# Altium schematic sheet GasCap.CMWX1ZZABZ-078.SchDoc
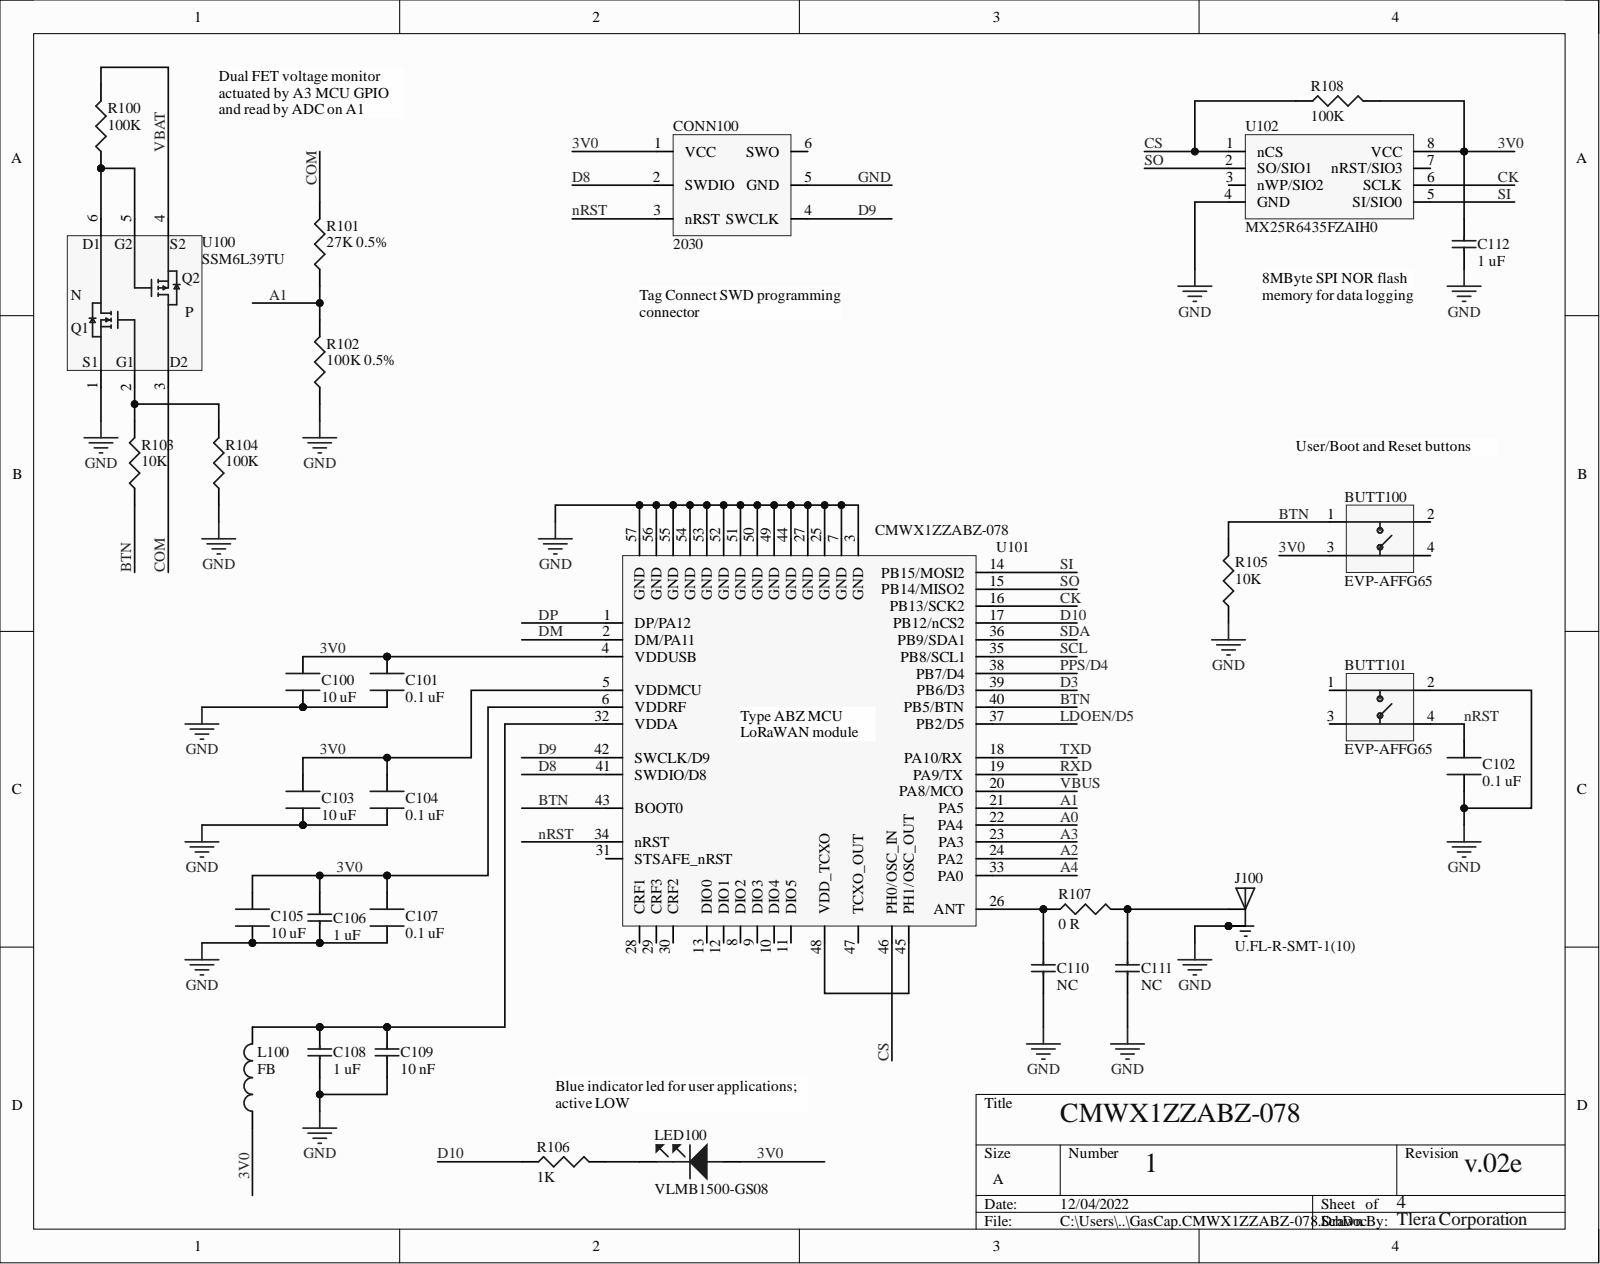
[diagram p0: GasCap.CMWX1ZZABZ-078.SchDoc]

## Parts
BUTT100  EVP-AFFG65  [EVP-AFFG65]
BUTT101  EVP-AFFG65  [EVP-AFFG65]
C100  10 uF  [402Cap]
C101  0.1 uF  [402Cap]
C102  0.1 uF  [402Cap]
C103  10 uF  [402Cap]
C104  0.1 uF  [402Cap]
C105  10 uF  [402Cap]
C106  1 uF  [402Cap]
C107  0.1 uF  [402Cap]
C108  1 uF  [402Cap]
C109  10 nF  [402Cap]
C110  NC  [402Cap]
C111  NC  [402Cap]
C112  1 uF  [402Cap]
CONN100  2030  [Tag_Connect_2030]
J100  U.FL-R-SMT-1(10)  [HRS_U.FL-R-SMT-1(10)]
L100  FB  [402IND]
LED100  VLMB1500-GS08  [0402LED]
R100  100K  [402RES]
R101  27K 0.5%  [402RES]
R102  100K 0.5%  [402RES]
R103  10K  [402RES]
R104  100K  [402RES]
R105  10K  [402RES]
R106  1K  [402RES]
R107  0 R  [402RES]
R108  100K  [402RES]
U100  SSM6L39TU  [SSM6L40TU]
U101  CMWX1ZZABZ-078  [XCVR_CMWX1ZZABZ-078]
U102  MX25R6435FZAIH0  [MX25R6435FZAIH0]

## Nets
3V0: BUTT100.3 C100.2 C101.2 C103.2 C104.2 C105.2 C106.2 C107.2 C112.2 CONN100.1(VCC) L100.1 LED100.2 R108.2 U101.4(VDDUSB) U101.5(VDDMCU) U101.6(VDDRF) U102.8(VCC)
A0: U101.22(PA4)
A1: R101.1 R102.2 U101.21(PA5)
A2: U101.24(PA2)
A3: U101.23(PA3)
A4: U101.33(PA0)
BTN: BUTT100.1 R103.1 R105.2 U101.40(PB5/BTN) U101.43(BOOT0)
CK: U101.16(PB13/SCK2) U102.6(SCLK)
COM: R101.2 U100.3
CS: R108.1 U101.46(PH0/OSC_IN) U102.1(nCS)
D3: U101.39(PB6/D3)
D8: CONN100.2(SWDIO) U101.41(SWDIO/D8)
D9: CONN100.4(SWCLK) U101.42(SWCLK/D9)
D10: R106.1 U101.17(PB12/nCS2)
DM: U101.2(DM/PA11)
DP: U101.1(DP/PA12)
GND: BUTT101.2 C100.1 C101.1 C102.1 C103.1 C104.1 C105.1 C106.1 C107.1 C108.1 C109.1 C110.1 C111.1 C112.1 CONN100.5(GND) J100.1 J100.3 R102.1 R104.1 R105.1 U100.1 U101.3(GND) U101.7(GND) U101.25(GND) U101.27(GND) U101.44(GND) U101.49(GND) U101.50(GND) U101.51(GND) U101.52(GND) U101.53(GND) U101.54(GND) U101.55(GND) U101.56(GND) U101.57(GND) U102.4(GND)
LDOEN/D5: U101.37(PB2/D5)
nRST: BUTT101.4 C102.2 CONN100.3(nRST) U101.34(nRST)
PPS/D4: U101.38(PB7/D4)
RXD: U101.19(PA9/TX)
SCL: U101.35(PB8/SCL1)
SDA: U101.36(PB9/SDA1)
SI: U101.14(PB15/MOSI2) U102.5(SI/SIO0)
SO: U101.15(PB14/MISO2) U102.2(SO/SIO1)
TXD: U101.18(PA10/RX)
VBAT: R100.2 U100.4
VBUS: U101.20(PA8/MCO)
NetC108_2: C108.2 C109.2 L100.2 U101.32(VDDA)
NetC110_2: C110.2 R107.1 U101.26(ANT)
NetC111_2: C111.2 J100.2 R107.2
NetLED100_1: LED100.1 R106.2
NetR100_1: R100.1 U100.5 U100.6(D1)
NetR103_2: R103.2 R104.2 U100.2
NetU101_45: U101.45(PH1/OSC_OUT) U101.48(VDD_TCXO)

Not connected: BUTT100 2 4; BUTT101 1 3; CONN100 6(SWO); U101 8(DIO2) 9(DIO3) 10(DIO4) 11(DIO5) 12(DIO1) 13(DIO0) 28(CRF1) 29(CRF3) 30(CRF2) 31(STSAFE_nRST) 47(TCXO_OUT); U102 3(nWP/SIO2) 7(nRST/SIO3)

## Library data of the parts
BUTT100 BUTT101 = EVP-AFFG65: Tactile Switches SPST-NO Force 160 gf OFF-(ON) .7mm H; Manufacturer: Panasonic; Category: Tactile Switches; Component Kind: Standard; ComponentLink1Description: https://datasheet.ciiva.com/16439/atk0000ce31-16439765.pdf?src-supplier=Future+Electronics; ComponentLink1URL: https://datasheet.ciiva.com/16439/atk0000ce31-16439765.pdf?src-supplier=Future+Electronics; ComponentLink2Description: https://datasheet.ciiva.com/7987/1679591-7987594.pdf?src-supplier=Element14; ComponentLink2URL: https://datasheet.ciiva.com/7987/1679591-7987594.pdf?src-supplier=Element14; Manufacturer Part Number: EVP-AFFG65; Pricing 1: 1=0.91000, 10=0.87400, 25=0.81720, 100=0.70940, 250=0.64556, 500=0.59846, 1000=0.55476, 2500=0.50433 (USD); RoHS: Yes; Stock 1: 7880; Supplier 1: Digi-Key; Supplier Part Number 1: P15511CT-ND; Library Name: Gnat.SchLib
C100 C103 C105 = CL05A106MQ5NUNC: 0402 10uF Ceramic Capacitor, Multilayer, Ceramic, 6.3V, 20% +Tol, 20% -Tol, X5R, 15% TC, 10uF, Surface Mount, 0402; Manufacturer: Samsung; Multilayer: Yes; Capacitance: 10uF; Capacitor Type: Ceramic; Category: Ceramic Capacitors; Component Kind: Standard; ComponentLink1Description: https://datasheet.ciiva.com:443/pdfs/VipMasterIC/IC/SEMS/SEMS-S-A0008521219/SEMS-S-A0008521300-1.pdf?src-supplier=IHS+Markit; ComponentLink1URL: https://datasheet.ciiva.com:443/pdfs/VipMasterIC/IC/SEMS/SEMS-S-A0008521219/SEMS-S-A0008521300-1.pdf?src-supplier=IHS+Markit; ComponentLink2Description: https://datasheet.ciiva.com/16437/cl05a106mq5nunc-16437356.pdf?src-supplier=Future+Electronics; ComponentLink2URL: https://datasheet.ciiva.com/16437/cl05a106mq5nunc-16437356.pdf?src-supplier=Future+Electronics; ComponentLink3Description: https://datasheet.ciiva.com/26877/getdatasheetpartid-355289-26877841.pdf?src-supplier=Verical; ComponentLink3URL: https://datasheet.ciiva.com/26877/getdatasheetpartid-355289-26877841.pdf?src-supplier=Verical; Dielectric Material: Ceramic; Height: 0.7mm; JESD-609 Code: e3; Length: 1mm; Manufacturer Part Number: CL05A106MQ5NUNC; Max Operating Temperature: 85°C; Min Operating Temperature: -55°C; Mount: Surface Mount; Negative Tolerance: 20%; Number of Pins: 2; Package Shape: Rectangular; Package Style: SMTMeter; Packaging: Tape and Reel; Positive Tolerance: 20%; Pricing 1: 1=0.26000, 10=0.17600, 50=0.10540, 100=0.08900, 500=0.06086, 1000=0.05384, 2500=0.05150, 5000=0.04682 (USD); Rated DC Voltage (URdc): 6.3V; REACH SVHC: Yes; RoHS: Yes; RoHS Compliant: Yes; Size Code: 0402; Stock 1: 9272723; Supplier 1: Digi-Key; Supplier Part Number 1: 1276-1451-1-ND; Temperature Characteristics Code: X5R; Terminal Finish: Nickel; Termination: Wraparound; Width: 0.5mm; Library Name: Gnat.SchLib
C101 C102 C104 C107 = CL05B104KO5NNNC: 0402 0.1 uF Ceramic Capacitor, Multilayer, Ceramic, 16V, 10% +Tol, 10% -Tol, X7R, 15% TC, 0.1uF, Surface Mount, 0402; Manufacturer: Samsung; Capacitance: 100nF; Multilayer: Yes; Capacitor Type: Ceramic; Category: Ceramic Capacitors; Component Kind: Standard; ComponentLink1Description: https://datasheet.ciiva.com:443/pdfs/VipMasterIC/IC/SEMS/SEMS-S-A0008384515/SEMS-S-A0008872921-1.pdf?src-supplier=IHS+Markit; ComponentLink1URL: https://datasheet.ciiva.com:443/pdfs/VipMasterIC/IC/SEMS/SEMS-S-A0008384515/SEMS-S-A0008872921-1.pdf?src-supplier=IHS+Markit; ComponentLink2Description: https://datasheet.ciiva.com/26920/getdatasheetpartid-359683-26920169.pdf?src-supplier=Verical; ComponentLink2URL: https://datasheet.ciiva.com/26920/getdatasheetpartid-359683-26920169.pdf?src-supplier=Verical; ComponentLink3Description: https://datasheet.ciiva.com/29198/458718-da-01-en-vs-kondensator-0402-29198423.pdf?src-supplier=Conrad; ComponentLink3URL: https://datasheet.ciiva.com/29198/458718-da-01-en-vs-kondensator-0402-29198423.pdf?src-supplier=Conrad; ComponentLink4Description: https://datasheet.ciiva.com/16208/cl05b104kq5nnnc-16208343.pdf?src-supplier=Future+Electronics; ComponentLink4URL: https://datasheet.ciiva.com/16208/cl05b104kq5nnnc-16208343.pdf?src-supplier=Future+Electronics; ComponentLink5Description: https://datasheet.ciiva.com/26309/mlcc-catalogdownload2013-eng-26309003.pdf?src-supplier=Distrelec; ComponentLink5URL: https://datasheet.ciiva.com/26309/mlcc-catalogdownload2013-eng-26309003.pdf?src-supplier=Distrelec; Dielectric Material: Ceramic; Height: 0.55mm; JESD-609 Code: e3; Length: 1mm; Manufacturer Part Number: CL05B104KO5NNNC; Max Operating Temperature: 125°C; Min Operating Temperature: -55°C; Mount: Surface Mount; Negative Tolerance: 10%; Number of Pins: 2; Package Shape: Rectangular; Package Style: SMTMeter; Packaging: Tape and Reel; Positive Tolerance: 10%; Pricing 1: 1=0.10000, 10=0.02600, 50=0.01400, 100=0.01150, 500=0.00820, 1000=0.00644, 2500=0.00586, 5000=0.00539 (USD); Rated DC Voltage (URdc): 16V; REACH SVHC: Yes; RoHS: Yes; RoHS Compliant: Yes; Size Code: 0402; Stock 1: 30141598; Supplier 1: Digi-Key; Supplier Part Number 1: 1276-1001-1-ND; Temperature Characteristics Code: X7R; Terminal Finish: Nickel; Termination: Wraparound; Width: 0.5mm; Library Name: Gnat.SchLib
C106 C108 C112 = GRT155R61C105KE01D: 0402 1 uF Ceramic Capacitor, Multilayer, Ceramic, 16V, 10% +Tol, 10% -Tol, X5R, 15% TC, 1uF, Surface Mount, 0402; Manufacturer: Murata; Multilayer: Yes; Reference Standard: AEC-Q200; Capacitance: 1uF; Capacitor Type: Ceramic; Category: Ceramic Capacitors; Component Kind: Standard; ComponentLink1Description: https://datasheet.ciiva.com:443/pdfs/VipMasterIC/IC/MURE/MURE-S-A0004410517/MURE-S-A0004410643-1.pdf?src-supplier=IHS+Markit; ComponentLink1URL: https://datasheet.ciiva.com:443/pdfs/VipMasterIC/IC/MURE/MURE-S-A0004410517/MURE-S-A0004410643-1.pdf?src-supplier=IHS+Markit; ComponentLink2Description: http://search.murata.co.jp/Ceramy/image/img/A01X/G101/ENG/GRT155R61C105KE01-01.pdf?src-supplier=Digi-Key; ComponentLink2URL: http://search.murata.co.jp/Ceramy/image/img/A01X/G101/ENG/GRT155R61C105KE01-01.pdf?src-supplier=Digi-Key; Dielectric Material: Ceramic; JESD-609 Code: e3; Manufacturer Part Number: GRT155R61C105KE01D; Max Operating Temperature: 85°C; Min Operating Temperature: -55°C; Mount: Surface Mount; Negative Tolerance: 10%; Number of Pins: 2; Package Shape: Rectangular; Packaging: Tape and Reel; Positive Tolerance: 10%; Pricing 1: 1=0.12000, 10=0.08300, 50=0.04740, 100=0.03920, 500=0.02752, 1000=0.02278, 2500=0.02120, 5000=0.01939 (USD); Rated DC Voltage (URdc): 16V; REACH SVHC: Yes; RoHS: Yes; RoHS Compliant: Yes; Size Code: 0402; Stock 1: 691618; Supplier 1: Digi-Key; Supplier Part Number 1: 490-12255-1-ND; Temperature Characteristics Code: X5R; Terminal Finish: Nickel; Termination: Wraparound
  C106 C108: Library Name: Gnat.SchLib
  C112: Library Name: GasCap.SchLib
C109 = 04023C103KAT2A: 0402 10 nF Ceramic Capacitor, Multilayer, Ceramic, 25V, 10% +Tol, 10% -Tol, X7R, 15% TC, 0.01uF, Surface Mount, 0402; Manufacturer: Kyocera AVX; Capacitance: 10nF; Multilayer: Yes; Capacitor Type: Ceramic; Category: Ceramic Capacitors; Component Kind: Standard; ComponentLink1Description: https://datasheet.ciiva.com:443/pdfs/VipMasterIC/IC/AVXC/AVXC-S-A0009640452/AVXC-S-A0009640452-1.pdf?src-supplier=IHS+Markit; ComponentLink1URL: https://datasheet.ciiva.com:443/pdfs/VipMasterIC/IC/AVXC/AVXC-S-A0009640452/AVXC-S-A0009640452-1.pdf?src-supplier=IHS+Markit; ComponentLink2Description: https://datasheet.ciiva.com/11788/0900766b80dc2409-11788540.pdf?src-supplier=RSComponents; ComponentLink2URL: https://datasheet.ciiva.com/11788/0900766b80dc2409-11788540.pdf?src-supplier=RSComponents; ComponentLink3Description: https://datasheet.ciiva.com/1123/cx7r-1123312.pdf?src-supplier=Element14; ComponentLink3URL: https://datasheet.ciiva.com/1123/cx7r-1123312.pdf?src-supplier=Element14; ComponentLink4Description: https://datasheet.ciiva.com/14590/70001075-14590631.pdf?src-supplier=Allied+Electronics; ComponentLink4URL: https://datasheet.ciiva.com/14590/70001075-14590631.pdf?src-supplier=Allied+Electronics; ComponentLink5Description: https://datasheet.ciiva.com/14596/70001075-14596734.pdf?src-supplier=Allied+Electronics; ComponentLink5URL: https://datasheet.ciiva.com/14596/70001075-14596734.pdf?src-supplier=Allied+Electronics; ComponentLink6Description: https://datasheet.ciiva.com/1123/1679506-1123313.pdf?src-supplier=Element14; ComponentLink6URL: https://datasheet.ciiva.com/1123/1679506-1123313.pdf?src-supplier=Element14; ComponentLink7Description: https://datasheet.ciiva.com/7709/1679506-7709526.pdf?src-supplier=Element14; ComponentLink7URL: https://datasheet.ciiva.com/7709/1679506-7709526.pdf?src-supplier=Element14; ComponentLink8Description: https://datasheet.ciiva.com/151/cx7r-151765.pdf?src-supplier=Digi-Key; ComponentLink8URL: https://datasheet.ciiva.com/151/cx7r-151765.pdf?src-supplier=Digi-Key; ComponentLink9Description: https://datasheet.ciiva.com/151/cx7r-151766.pdf?src-supplier=Digi-Key; ComponentLink9URL: https://datasheet.ciiva.com/151/cx7r-151766.pdf?src-supplier=Digi-Key; ComponentLink10Description: https://datasheet.ciiva.com/151/cx7r-151767.pdf?src-supplier=Digi-Key; ComponentLink10URL: https://datasheet.ciiva.com/151/cx7r-151767.pdf?src-supplier=Digi-Key; Dielectric Material: Ceramic; Height: 0.56mm; JESD-609 Code: e3; Length: 1mm; Manufacturer Part Number: 04023C103KAT2A; Max Operating Temperature: 125°C; Min Operating Temperature: -55°C; Mount: Surface Mount; Negative Tolerance: 10%; Number of Pins: 2; Package Shape: Rectangular; Package Style: SMTMeter; Packaging: Tape and Reel; Positive Tolerance: 10%; Pricing 1: 1=0.10000, 10=0.01600, 50=0.00860, 100=0.00700, 500=0.00500, 1000=0.00393, 2500=0.00358, 5000=0.00329 (USD); Rated DC Voltage (URdc): 25V; REACH SVHC: Yes; RoHS: Yes; RoHS Compliant: Yes; Size Code: 0402; Stock 1: 3781761; Supplier 1: Digi-Key; Supplier Part Number 1: 478-1113-1-ND; Temperature Characteristics Code: X7R; Terminal Finish: Nickel; Termination: Wraparound; Width: 0.5mm; Library Name: Gnat.SchLib
C110 C111 = C0402-N.C.: Not mounted; Artikkelnr Noca: N.A.; Assembly: Not mounted; Note: Chip Cap; Pad Count: 2; Value: N.C.; Component Class: Capacitors; Component Kind: Standard; ComponentLink1Description: Datasheet; ComponentLink1URL: N.A.; ComponentLink2Description: Supplier link; ComponentLink2URL: N.A.; Footprint Doc: 0402; HelpURL: N.A.; LatestRevisionDate: 2009-05-08; Manufacturer: N.A.; Manufacturer Part Number: N.A.; Part Number: C0402-N.C.; Part number IPS: N.A.; Part number Norautron: N.A.; PartId: 100200105; Published: 2009-05-08; Supplier 1: N.A.; Supplier 2: N.A.; Supplier Part Number 1: N.A.; Supplier Part Number 2: N.A.; Author: rsk; Library Name: GasCap.SchLib
CONN100 = Tag_Connect_2030: Tag Connect 2030; Component Kind: Standard; Library Name: GasCap.SchLib
J100 = U.FL-R-SMT-1(10): U.FL Series 6 Ghz 50 Ohm Ultra-small SMT Coaxial Cable Receptacle; Availability: Unavailable; Component Kind: Standard; MP: U.FL-R-SMT-1(10); Package: None; Price: None; Library Name: Gnat.SchLib
L100 = BLM15HD102SN1D: 0402 Ferrite Chip, 1 Function(s), 0.25A, 2 Pin(s); Manufacturer: Murata; Manufacturer Part Number: BLM15AX121SN1D; Current Rating: 700mA; DC Resistance-Max: 130mR; Max Frequency: 100MHz; Number of Functions: 1; Output Impedance: 120R; Case Code: 0402; Category: Inductors; Component Kind: Standard; ComponentLink1Description: https://datasheet.ciiva.com:443/pdfs/VipMasterIC/IC/MURE/MURE-S-A0010009827/MURE-S-A0010009827-1.pdf?src-supplier=IHS+Markit; ComponentLink1URL: https://datasheet.ciiva.com:443/pdfs/VipMasterIC/IC/MURE/MURE-S-A0010009827/MURE-S-A0010009827-1.pdf?src-supplier=IHS+Markit; ComponentLink2Description: https://datasheet.ciiva.com/41449/001172167-da-01-en-drossel-0402-120r-1-0x0-5mm-10000st-41449456.pdf?src-supplier=Conrad; ComponentLink2URL: https://datasheet.ciiva.com/41449/001172167-da-01-en-drossel-0402-120r-1-0x0-5mm-10000st-41449456.pdf?src-supplier=Conrad; ComponentLink3Description: https://datasheet.ciiva.com/1012/l0110e-1012387.pdf?src-supplier=Digi-Key; ComponentLink3URL: https://datasheet.ciiva.com/1012/l0110e-1012387.pdf?src-supplier=Digi-Key; ComponentLink4Description: https://datasheet.ciiva.com/1012/l0110e-1012386.pdf?src-supplier=Digi-Key; ComponentLink4URL: https://datasheet.ciiva.com/1012/l0110e-1012386.pdf?src-supplier=Digi-Key; ComponentLink5Description: https://datasheet.ciiva.com/1012/l0110e-1012388.pdf?src-supplier=Digi-Key; ComponentLink5URL: https://datasheet.ciiva.com/1012/l0110e-1012388.pdf?src-supplier=Digi-Key; ComponentLink6Description: https://datasheet.ciiva.com:443/pdfs/VipMasterIC/IC/MURE/MURE-S-A0008871331/MURE-S-A0008871390-1.pdf?src-supplier=IHS+Markit; ComponentLink6URL: https://datasheet.ciiva.com:443/pdfs/VipMasterIC/IC/MURE/MURE-S-A0008871331/MURE-S-A0008871390-1.pdf?src-supplier=IHS+Markit; ComponentLink7Description: https://datasheet.ciiva.com/27054/1844707-27054220.pdf?src-supplier=Jameco; ComponentLink7URL: https://datasheet.ciiva.com/27054/1844707-27054220.pdf?src-supplier=Jameco; ComponentLink8Description: https://datasheet.ciiva.com/11799/0900766b811b5866-11799124.pdf?src-supplier=RSComponents; ComponentLink8URL: https://datasheet.ciiva.com/11799/0900766b811b5866-11799124.pdf?src-supplier=RSComponents; ComponentLink9Description: https://datasheet.ciiva.com/18089/getdatasheetpartid-153027-18089625.pdf?src-supplier=Verical; ComponentLink9URL: https://datasheet.ciiva.com/18089/getdatasheetpartid-153027-18089625.pdf?src-supplier=Verical; ComponentLink10Description: https://datasheet.ciiva.com/41425/001171683-da-01-en-chip-drossel0402-1000r-250ma-1-0x0-5mm-41425767.pdf?src-supplier=Conrad; ComponentLink10URL: https://datasheet.ciiva.com/41425/001171683-da-01-en-chip-drossel0402-1000r-250ma-1-0x0-5mm-41425767.pdf?src-supplier=Conrad; ComponentLink11Description: https://datasheet.ciiva.com/41449/001172200-da-01-en-drossel-0402-1000r-21-0x0-5mm-10000st-41449488.pdf?src-supplier=Conrad; ComponentLink11URL: https://datasheet.ciiva.com/41449/001172200-da-01-en-drossel-0402-1000r-21-0x0-5mm-10000st-41449488.pdf?src-supplier=Conrad; ComponentLink12Description: https://datasheet.ciiva.com/1466/5168-1466860.pdf?src-supplier=Element14; ComponentLink12URL: https://datasheet.ciiva.com/1466/5168-1466860.pdf?src-supplier=Element14; ComponentLink13Description: https://datasheet.ciiva.com/1466/5168-1466859.pdf?src-supplier=Element14; ComponentLink13URL: https://datasheet.ciiva.com/1466/5168-1466859.pdf?src-supplier=Element14; ComponentLink14Description: https://datasheet.ciiva.com/1012/l0110s0101blm15h-1012795.pdf?src-supplier=Digi-Key; ComponentLink14URL: https://datasheet.ciiva.com/1012/l0110s0101blm15h-1012795.pdf?src-supplier=Digi-Key; ComponentLink15Description: https://datasheet.ciiva.com/1012/l0110s0101blm15h-1012794.pdf?src-supplier=Digi-Key; ComponentLink15URL: https://datasheet.ciiva.com/1012/l0110s0101blm15h-1012794.pdf?src-supplier=Digi-Key; ComponentLink16Description: https://datasheet.ciiva.com/1012/l0110s0101blm15h-1012796.pdf?src-supplier=Digi-Key; ComponentLink16URL: https://datasheet.ciiva.com/1012/l0110s0101blm15h-1012796.pdf?src-supplier=Digi-Key; ComponentLink17Description: https://datasheet.ciiva.com/3081/l0110s0101blm15h-3081604.pdf?src-supplier=Avnet; ComponentLink17URL: https://datasheet.ciiva.com/3081/l0110s0101blm15h-3081604.pdf?src-supplier=Avnet; ComponentLink18Description: https://datasheet.ciiva.com/16373/l0110s0101blm15h-16373866.pdf?src-supplier=Future+Electronics; ComponentLink18URL: https://datasheet.ciiva.com/16373/l0110s0101blm15h-16373866.pdf?src-supplier=Future+Electronics; ComponentLink19Description: https://datasheet.ciiva.com/3081/l0110s0101blm15h-3081605.pdf?src-supplier=Avnet; ComponentLink19URL: https://datasheet.ciiva.com/3081/l0110s0101blm15h-3081605.pdf?src-supplier=Avnet; Construction: Chip Bead; Filter Type: FERRITE CHIP; Height: 0.5mm; JESD-609 Code: e3; Length: 1mm; Material: Ferrite; Max Operating Temperature: 125°C; Min Frequency: 100MHz; Min Operating Temperature: -55°C; Mount: Surface Mount; Packaging: Tape and Reel; Pricing 1: 1=0.10000, 10=0.06700, 25=0.06040, 50=0.05440, 100=0.03990, 250=0.02904, 500=0.02662, 1000=0.02299, 5000=0.01815 (USD); Pricing 2: 1=0.14000, 10=0.11200, 25=0.08680, 50=0.07180, 100=0.06200, 250=0.04956, 500=0.04460, 1000=0.03717, 5000=0.03469 (USD); REACH SVHC: Yes; RoHS: Yes; RoHS Compliant: Yes; Stock 1: 46609; Stock 2: 408631; Supplier 1: Digi-Key; Supplier 2: Digi-Key; Supplier Part Number 1: 490-5439-1-ND; Supplier Part Number 2: 490-4003-1-ND; Terminal Finish: Tin; Width: 0.5mm; Library Name: Gnat.SchLib
LED100 = VLMB1500-GS08: Single Color LED, Blue, 0.5mm; Manufacturer: Vishay; Forward Current-Max: 30mA; Forward Voltage-Max: 3.15V; Luminous Intensity-Nom: 28.1mcd; Number of Functions: 1; Overall Height: 0.35mm; Shape: Rectangular; Viewing Angle: 130°; Category: LEDs; Color: Blue; Color@wavelength: Blue; Component Kind: Standard; ComponentLink1Description: https://datasheet.ciiva.com:443/pdfs/VipMasterIC/IC/VISH/VISH-S-A0003457490/VISH-S-A0003457490-1.pdf?src-supplier=IHS+Markit; ComponentLink1URL: https://datasheet.ciiva.com:443/pdfs/VipMasterIC/IC/VISH/VISH-S-A0003457490/VISH-S-A0003457490-1.pdf?src-supplier=IHS+Markit; ComponentLink2Description: https://datasheet.ciiva.com/30005/vlmo1500-30005132.pdf?src-supplier=Avnet; ComponentLink2URL: https://datasheet.ciiva.com/30005/vlmo1500-30005132.pdf?src-supplier=Avnet; JESD-609 Code: e3; Manufacturer Part Number: VLMB1500-GS08; Max Operating Temperature: 85°C; Min Operating Temperature: -30°C; Mount: Surface Mount; Number of Pins: 2; Optoelectronic Device Type: SINGLE COLOR LED; Packaging: Tape and Reel; Pricing 1: 1=0.50000, 10=0.37300, 100=0.21130, 500=0.13996, 1000=0.10730 (USD); REACH SVHC: Yes; RoHS: Yes; RoHS Compliant: Yes; Size: 0.5mm; Stock 1: 61978; Supplier 1: Digi-Key; Supplier Part Number 1: VLMB1500-GS08DKR-ND; Terminal Finish: Tin; Terminal Pitch: 0.95mm; Library Name: Gnat.SchLib
R100 R104 R108 = RMCF0402JT100K: 0402 100K Fixed Resistor, Metal Glaze/thick Film, 0.063W, 100000ohm, 50V, 5% +/-Tol, 100ppm/Cel, Surface Mount, 0402; Manufacturer: Stackpole Electronics; Package Height: 0.3mm; Package Length: 1mm; Package Width: 0.5mm; Rated Power Dissipation (P): 63mW; Rated Temperature: 70°C; Reference Standard: AEC-Q200; Resistance: 100kR; Temperature Coefficient: 100ppm/°C; Category: Chip SMD Resistors; Component Kind: Standard; ComponentLink1Description: https://datasheet.ciiva.com:443/pdfs/VipMasterIC/IC/SEIE/SEIE-S-A0009541969/SEIE-S-A0009541969-1.pdf?src-supplier=IHS+Markit; ComponentLink1URL: https://datasheet.ciiva.com:443/pdfs/VipMasterIC/IC/SEIE/SEIE-S-A0009541969/SEIE-S-A0009541969-1.pdf?src-supplier=IHS+Markit; ComponentLink2Description: https://datasheet.ciiva.com/15193/getdatasheetpartid-410325-15193429.pdf?src-supplier=Verical; ComponentLink2URL: https://datasheet.ciiva.com/15193/getdatasheetpartid-410325-15193429.pdf?src-supplier=Verical; Construction: Rectangular; JESD-609 Code: e3; Manufacturer Part Number: RMCF0402JT100K; Max Operating Temperature: 155°C; Min Operating Temperature: -55°C; Mount: Surface Mount; Number of Pins: 2; Package Shape: Rectangular; Package Style: SMTMeter; Packaging: Tape and Reel; Pricing 1: 1=0.10000, 10=0.01200, 100=0.00470, 1000=0.00213, 2500=0.00185, 5000=0.00153 (USD); REACH SVHC: Yes; Resistor Type: FIXED RESISTOR; RoHS: Yes; RoHS Compliant: Yes; Size Code: 0402; Stock 1: 6317880; Supplier 1: Digi-Key; Supplier Part Number 1: RMCF0402JT100KCT-ND; Technology: METAL GLAZE/THICK FILM; Terminal Finish: Nickel; Termination: Wraparound; Tolerance: 5%; Working Voltage: 50V
  R100 R104: Library Name: Gnat.SchLib
  R108: Library Name: GasCap.SchLib
R101 = RT0402DRE0727KL: 0402 27K Fixed Resistor, Thin Film, 0.0625W, 27000ohm, 50V, 0.5% +/-Tol, 50ppm/Cel, Surface Mount, 0402; Manufacturer: Yageo; Additional Feature: HIGH PRECISION; Package Height: 0.3mm; Package Length: 1mm; Package Width: 0.5mm; Rated Power Dissipation (P): 62.5mW; Rated Temperature: 70°C; Resistance: 27kR; Temperature Coefficient: 50ppm/°C; Category: Chip SMD Resistors; Component Kind: Standard; ComponentLink1Description: https://datasheet.ciiva.com:443/pdfs/VipMasterIC/IC/YAGO/YAGO-S-A0008510989/YAGO-S-A0008510989-1.pdf?src-supplier=IHS+Markit; ComponentLink1URL: https://datasheet.ciiva.com:443/pdfs/VipMasterIC/IC/YAGO/YAGO-S-A0008510989/YAGO-S-A0008510989-1.pdf?src-supplier=IHS+Markit; ComponentLink2Description: https://datasheet.ciiva.com/2572/pyu-rt-1-to-0-05-rohs-l-5-2572295.pdf?src-supplier=Digi-Key; ComponentLink2URL: https://datasheet.ciiva.com/2572/pyu-rt-1-to-0-05-rohs-l-5-2572295.pdf?src-supplier=Digi-Key; Construction: Chip; Manufacturer Part Number: RT0402DRE0727KL; Max Operating Temperature: 155°C; Min Operating Temperature: -55°C; Mount: Surface Mount; Number of Pins: 2; Package Style: SMTMeter; Packaging: Tape and Reel; Pricing 1: 1=0.18000, 10=0.15100, 100=0.05920, 1000=0.02478, 2500=0.02271, 5000=0.02065 (USD); REACH SVHC: Yes; Resistor Type: FIXED RESISTOR; RoHS: Yes; RoHS Compliant: Yes; Size Code: 0402; Stock 1: 11400; Supplier 1: Digi-Key; Supplier Part Number 1: 311-2262-1-ND; Technology: THIN FILM; Termination: Wraparound; Tolerance: 0.5%; Working Voltage: 50V; Library Name: Gnat.SchLib
R102 = RC0402DR-07100KL: 0402 100K Fixed Resistor, Metal Glaze/thick Film, 0.0625W, 100000ohm, 50V, 0.5% +/-Tol, 100ppm/Cel, Surface Mount, 0402; Manufacturer: Yageo; Additional Feature: LASER TRIMMABLE; Package Height: 0.35mm; Package Length: 1mm; Package Width: 0.5mm; Rated Power Dissipation (P): 62.5mW; Rated Temperature: 70°C; Resistance: 100kR; Temperature Coefficient: 100ppm/°C; Category: Chip SMD Resistors; Component Kind: Standard; ComponentLink1Description: https://datasheet.ciiva.com:443/pdfs/VipMasterIC/IC/YAGO/YAGO-S-A0008145938/YAGO-S-A0008145938-1.pdf?src-supplier=IHS+Markit; ComponentLink1URL: https://datasheet.ciiva.com:443/pdfs/VipMasterIC/IC/YAGO/YAGO-S-A0008145938/YAGO-S-A0008145938-1.pdf?src-supplier=IHS+Markit; ComponentLink2Description: https://datasheet.ciiva.com/16740/pyu-rc0402-51-rohs-l-6-16740735.pdf?src-supplier=Future+Electronics; ComponentLink2URL: https://datasheet.ciiva.com/16740/pyu-rc0402-51-rohs-l-6-16740735.pdf?src-supplier=Future+Electronics; Construction: Chip; JESD-609 Code: e3; Manufacturer Part Number: RC0402DR-07100KL; Max Operating Temperature: 155°C; Min Operating Temperature: -55°C; Mount: Surface Mount; Number of Pins: 2; Package Shape: Rectangular; Package Style: SMTMeter; Packaging: Tape and Reel; Pricing 1: 1=0.10000, 10=0.06400, 100=0.02590, 1000=0.01164, 2500=0.01010, 5000=0.00835 (USD); REACH SVHC: Yes; Resistor Type: FIXED RESISTOR; RoHS: Yes; RoHS Compliant: Yes; Size Code: 0402; Stock 1: 75058; Supplier 1: Digi-Key; Supplier Part Number 1: 13-RC0402DR-07100KLCT-ND; Technology: METAL GLAZE/THICK FILM; Terminal Finish: Nickel; Termination: Wraparound; Tolerance: 0.5%; Working Voltage: 50V; Library Name: Gnat.SchLib
R103 R105 = CR0402-FX-1002GLF: 0402 10K Fixed Resistor, Metal Glaze/thick Film, 0.0625W, 10000ohm, 50V, 1% +/-Tol, 100ppm/Cel, Surface Mount, 0402; Manufacturer: Bourns; Package Height: 0.35mm; Package Length: 1mm; Package Width: 0.5mm; Rated Power Dissipation (P): 62.5mW; Rated Temperature: 70°C; Resistance: 10kR; Temperature Coefficient: 100ppm/°C; Category: Chip SMD Resistors; Component Kind: Standard; ComponentLink1Description: https://datasheet.ciiva.com:443/pdfs/VipMasterIC/IC/BOUR/BOUR-S-A0008953887/BOUR-S-A0008953887-1.pdf?src-supplier=IHS+Markit; ComponentLink1URL: https://datasheet.ciiva.com:443/pdfs/VipMasterIC/IC/BOUR/BOUR-S-A0008953887/BOUR-S-A0008953887-1.pdf?src-supplier=IHS+Markit; ComponentLink2Description: https://datasheet.ciiva.com/30490/0900766b812730ae-30490501.pdf?src-supplier=RSComponents; ComponentLink2URL: https://datasheet.ciiva.com/30490/0900766b812730ae-30490501.pdf?src-supplier=RSComponents; ComponentLink3Description: https://datasheet.ciiva.com/3255/cr0402-3255916.pdf?src-supplier=Avnet; ComponentLink3URL: https://datasheet.ciiva.com/3255/cr0402-3255916.pdf?src-supplier=Avnet; ComponentLink4Description: https://datasheet.ciiva.com/6562/cr0402-6562871.pdf?src-supplier=Digi-Key; ComponentLink4URL: https://datasheet.ciiva.com/6562/cr0402-6562871.pdf?src-supplier=Digi-Key; ComponentLink5Description: https://datasheet.ciiva.com/6562/cr0402-6562873.pdf?src-supplier=Digi-Key; ComponentLink5URL: https://datasheet.ciiva.com/6562/cr0402-6562873.pdf?src-supplier=Digi-Key; ComponentLink6Description: https://datasheet.ciiva.com/6562/cr0402-6562911.pdf?src-supplier=Digi-Key; ComponentLink6URL: https://datasheet.ciiva.com/6562/cr0402-6562911.pdf?src-supplier=Digi-Key; ComponentLink7Description: https://datasheet.ciiva.com/7606/1896412-7606203.pdf?src-supplier=Newark; ComponentLink7URL: https://datasheet.ciiva.com/7606/1896412-7606203.pdf?src-supplier=Newark; ComponentLink8Description: https://datasheet.ciiva.com/22644/659744-22644166.pdf?src-supplier=Element14; ComponentLink8URL: https://datasheet.ciiva.com/22644/659744-22644166.pdf?src-supplier=Element14; ComponentLink9Description: https://datasheet.ciiva.com/22713/659744-22713205.pdf?src-supplier=Element14; ComponentLink9URL: https://datasheet.ciiva.com/22713/659744-22713205.pdf?src-supplier=Element14; ComponentLink10Description: https://datasheet.ciiva.com/30133/cr0402-30133006.pdf?src-supplier=Onlinecomponents.com; ComponentLink10URL: https://datasheet.ciiva.com/30133/cr0402-30133006.pdf?src-supplier=Onlinecomponents.com; Construction: Chip; JESD-609 Code: e3; Manufacturer Part Number: CR0402-FX-1002GLF; Max Operating Temperature: 125°C; Min Operating Temperature: -55°C; Mount: Surface Mount; Number of Pins: 2; Package Shape: Rectangular; Package Style: SMTMeter; Packaging: Tape and Reel; Pricing 1: 1=0.10000, 10=0.01000, 100=0.00350, 1000=0.00158, 2500=0.00138, 5000=0.00114 (USD); REACH SVHC: Yes; Resistor Type: FIXED RESISTOR; RoHS: Yes; RoHS Compliant: Yes; Size Code: 0402; Stock 1: 1486885; Supplier 1: Digi-Key; Supplier Part Number 1: CR0402-FX-1002GLFDKR-ND; Technology: RUTHENIUM OXIDE; Terminal Finish: Nickel; Termination: Wraparound; Tolerance: 1%; Working Voltage: 50V; Library Name: Gnat.SchLib
R106 = RMCF0402FT1K00: 0402 1K Fixed Resistor, Metal Glaze/thick Film, 0.063W, 1000ohm, 50V, 1% +/-Tol, 100ppm/Cel, Surface Mount, 0402; Manufacturer: Stackpole Electronics; Package Height: 0.3mm; Package Length: 1mm; Package Width: 0.5mm; Rated Power Dissipation (P): 63mW; Rated Temperature: 70°C; Reference Standard: AEC-Q200; Resistance: 1kR; Temperature Coefficient: 100ppm/°C; Category: Chip SMD Resistors; Component Kind: Standard; ComponentLink1Description: https://datasheet.ciiva.com:443/pdfs/VipMasterIC/IC/SEIE/SEIE-S-A0009541969/SEIE-S-A0009541969-1.pdf?src-supplier=IHS+Markit; ComponentLink1URL: https://datasheet.ciiva.com:443/pdfs/VipMasterIC/IC/SEIE/SEIE-S-A0009541969/SEIE-S-A0009541969-1.pdf?src-supplier=IHS+Markit; ComponentLink2Description: https://datasheet.ciiva.com/15193/getdatasheetpartid-365385-15193418.pdf?src-supplier=Verical; ComponentLink2URL: https://datasheet.ciiva.com/15193/getdatasheetpartid-365385-15193418.pdf?src-supplier=Verical; ComponentLink3Description: https://datasheet.ciiva.com/24336/sei-rmcp-24336266.pdf?src-supplier=Onlinecomponents.com; ComponentLink3URL: https://datasheet.ciiva.com/24336/sei-rmcp-24336266.pdf?src-supplier=Onlinecomponents.com; Construction: Rectangular; JESD-609 Code: e3; Manufacturer Part Number: RMCF0402FT1K00; Max Operating Temperature: 155°C; Min Operating Temperature: -55°C; Mount: Surface Mount; Number of Pins: 2; Package Shape: Rectangular; Package Style: SMTMeter; Packaging: Tape and Reel; Pricing 1: 1=0.10000, 10=0.01500, 100=0.00610, 1000=0.00274, 2500=0.00238, 5000=0.00197 (USD); REACH SVHC: Yes; Resistor Type: FIXED RESISTOR; RoHS: Yes; RoHS Compliant: Yes; Size Code: 0402; Stock 1: 9359135; Supplier 1: Digi-Key; Supplier Part Number 1: RMCF0402FT1K00CT-ND; Technology: METAL GLAZE/THICK FILM; Terminal Finish: Nickel; Termination: Wraparound; Tolerance: 1%; Working Voltage: 50V; Library Name: Gnat.SchLib
R107 = RMCF0402ZT0R00: 0402 0R Fixed Resistor, Metal Glaze/thick Film, 0.063W, 0ohm, Surface Mount, 0402; Manufacturer: Stackpole Electronics; Package Height: 0.3mm; Package Length: 1mm; Package Width: 0.5mm; Rated Power Dissipation (P): 63mW; Rated Temperature: 70°C; Reference Standard: AEC-Q200; Working Voltage: 50V; Category: Chip SMD Resistors; Component Kind: Standard; ComponentLink1Description: https://datasheet.ciiva.com:443/pdfs/VipMasterIC/IC/SEIE/SEIE-S-A0007077258/SEIE-S-A0007077258-1.pdf?src-supplier=IHS+Markit; ComponentLink1URL: https://datasheet.ciiva.com:443/pdfs/VipMasterIC/IC/SEIE/SEIE-S-A0007077258/SEIE-S-A0007077258-1.pdf?src-supplier=IHS+Markit; ComponentLink2Description: https://datasheet.ciiva.com/26835/getdatasheetpartid-409751-26835612.pdf?src-supplier=Verical; ComponentLink2URL: https://datasheet.ciiva.com/26835/getdatasheetpartid-409751-26835612.pdf?src-supplier=Verical; Construction: Rectangular; JESD-609 Code: e3; Manufacturer Part Number: RMCF0402ZT0R00; Max Operating Temperature: 155°C; Min Operating Temperature: -55°C; Mount: Surface Mount; Number of Pins: 2; Package Shape: Rectangular; Package Style: SMTMeter; Packaging: Tape and Reel; Pricing 1: 1=0.10000, 10=0.01000, 25=0.00720, 50=0.00560, 100=0.00410, 250=0.00316, 500=0.00252, 1000=0.00186, 5000=0.00133 (USD); REACH SVHC: Yes; Resistance: 0R; Resistor Type: FIXED RESISTOR; RoHS: Yes; RoHS Compliant: Yes; Size Code: 0402; Stock 1: 19021765; Supplier 1: Digi-Key; Supplier Part Number 1: RMCF0402ZT0R00CT-ND; Technology: METAL GLAZE/THICK FILM; Terminal Finish: Nickel; Termination: Wraparound; Library Name: GasCap.SchLib
U100 = SSM6L39TU: Trans MOSFET Array N/P-CH 30V/-30V 1.6A/-1.4A 6-Pin UF; Manufacturer: Toshiba; Manufacturer Part Number: SSM6L40TU,LF; Component Kind: Standard; Pricing 1: 1=0.51000, 10=0.41400, 50=0.41400, 100=0.28200, 1000=0.15900, 10000=0.13600 (USD); RoHS: No; Supplier 1: Mouser; Supplier Part Number 1: 757-SSM6L40TULF; Library Name: Gnat.SchLib
U101 = CMWX1ZZABZ-078: General ISM < 1GHz LoRa™ Transceiver Module 868MHz, 915MHz Surface Mount; Manufacturer: Murata; Category: RF Receivers, Transceivers; Component Kind: Standard; ComponentLink1Description: https://wireless.murata.com/RFM/data/type_abz.pdf?src-supplier=Digi-Key; ComponentLink1URL: https://wireless.murata.com/RFM/data/type_abz.pdf?src-supplier=Digi-Key; Manufacturer Part Number: CMWX1ZZABZ-078; Pricing 1: 1=15.80000, 10=14.31800, 25=13.20800, 50=13.07600, 100=12.08600, 250=11.34000, 500=11.17300, 1000=11.00600 (USD); RoHS: Yes; Stock 1: 986; Supplier 1: Arrow Electronics; Supplier Part Number 1: CMWX1ZZABZ-078; Library Name: Gnat.SchLib
U102 = MX25R6435FZAIH0: Flash, 16MX4, PDSO8; Manufacturer: Macronix; Alternate Memory Width: 2; Clock Frequency-Max (fCLK): 80MHz; Density: 67108864b; Memory Width: 4; Number of Functions: 1; Number of Words: 16777216; Number of Words Code: 16M; Operating Mode: SYNCHRONOUS; Package Body Material: Plastic; Parallel/Serial: SERIAL; Programming Voltage: 1.8V; Supply Voltage-Max (Vsup): 3.6V; Supply Voltage-Min (Vsup): 1.65V; Category: Memory; Component Kind: Standard; ComponentLink1Description: https://datasheet.ciiva.com:443/pdfs/VipMasterIC/IC/MXMA/MXMA-S-A0003161387/MXMA-S-A0003161387-1.pdf?src-supplier=IHS+Markit; ComponentLink1URL: https://datasheet.ciiva.com:443/pdfs/VipMasterIC/IC/MXMA/MXMA-S-A0003161387/MXMA-S-A0003161387-1.pdf?src-supplier=IHS+Markit; ComponentLink2Description: http://www.farnell.com/datasheets/2815329.pdf?src-supplier=Farnell; ComponentLink2URL: http://www.farnell.com/datasheets/2815329.pdf?src-supplier=Farnell; ComponentLink3Description: http://ckassoc.com/oldsite/pdf/Macronix_Product_Line_Card_July_2017_General%20_070617.pdf?src-supplier=Digi-Key; ComponentLink3URL: http://ckassoc.com/oldsite/pdf/Macronix_Product_Line_Card_July_2017_General%20_070617.pdf?src-supplier=Digi-Key; ComponentLink4Description: http://www.farnell.com/datasheets/2815329.pdf?src-supplier=Newark; ComponentLink4URL: http://www.farnell.com/datasheets/2815329.pdf?src-supplier=Newark; ComponentLink5Description: http://www.farnell.com/datasheets/2815329.pdf?src-supplier=Element14; ComponentLink5URL: http://www.farnell.com/datasheets/2815329.pdf?src-supplier=Element14; Height - Seated (Max): 0.6mm; JESD-30 Code: S-PDSO-N8; Length: 4mm; Manufacturer Part Number: MX25R6435FZAIH0; Max Operating Temperature: 85°C; Memory Type: FLASH; Min Operating Temperature: -40°C; Number of Pins: 8; Organization: 16MX4; Package Code: HVSON; Package Shape: Square; Package Style: SMALL OUTLINE, HEAT SINK/SLUG, VERY THIN PROFILEMeter; Pricing 1: 1=1.93100, 10=1.76000, 25=1.71400, 40=1.70500, 80=1.46700, 490=1.45200, 980=1.33800, 4900=1.28200 (USD); REACH SVHC: Yes; RoHS: Yes; RoHS Compliant: Yes; Stock 1: 4700; Supplier 1: Arrow Electronics; Supplier Part Number 1: MX25R6435FZAIH0; Supply Voltage-Nom (Vsup): 1.8V; Surface Mount: Yes; Technology: CMOS; Temperature Grade: INDUSTRIAL; Terminal Form: No Lead; Terminal Pitch: 0.8mm; Terminal Position: DUAL; Width: 4mm; Library Name: GasCap.SchLib
